# Altium schematic sheet PAGE2-CCTALK CONVERTER.SchDoc
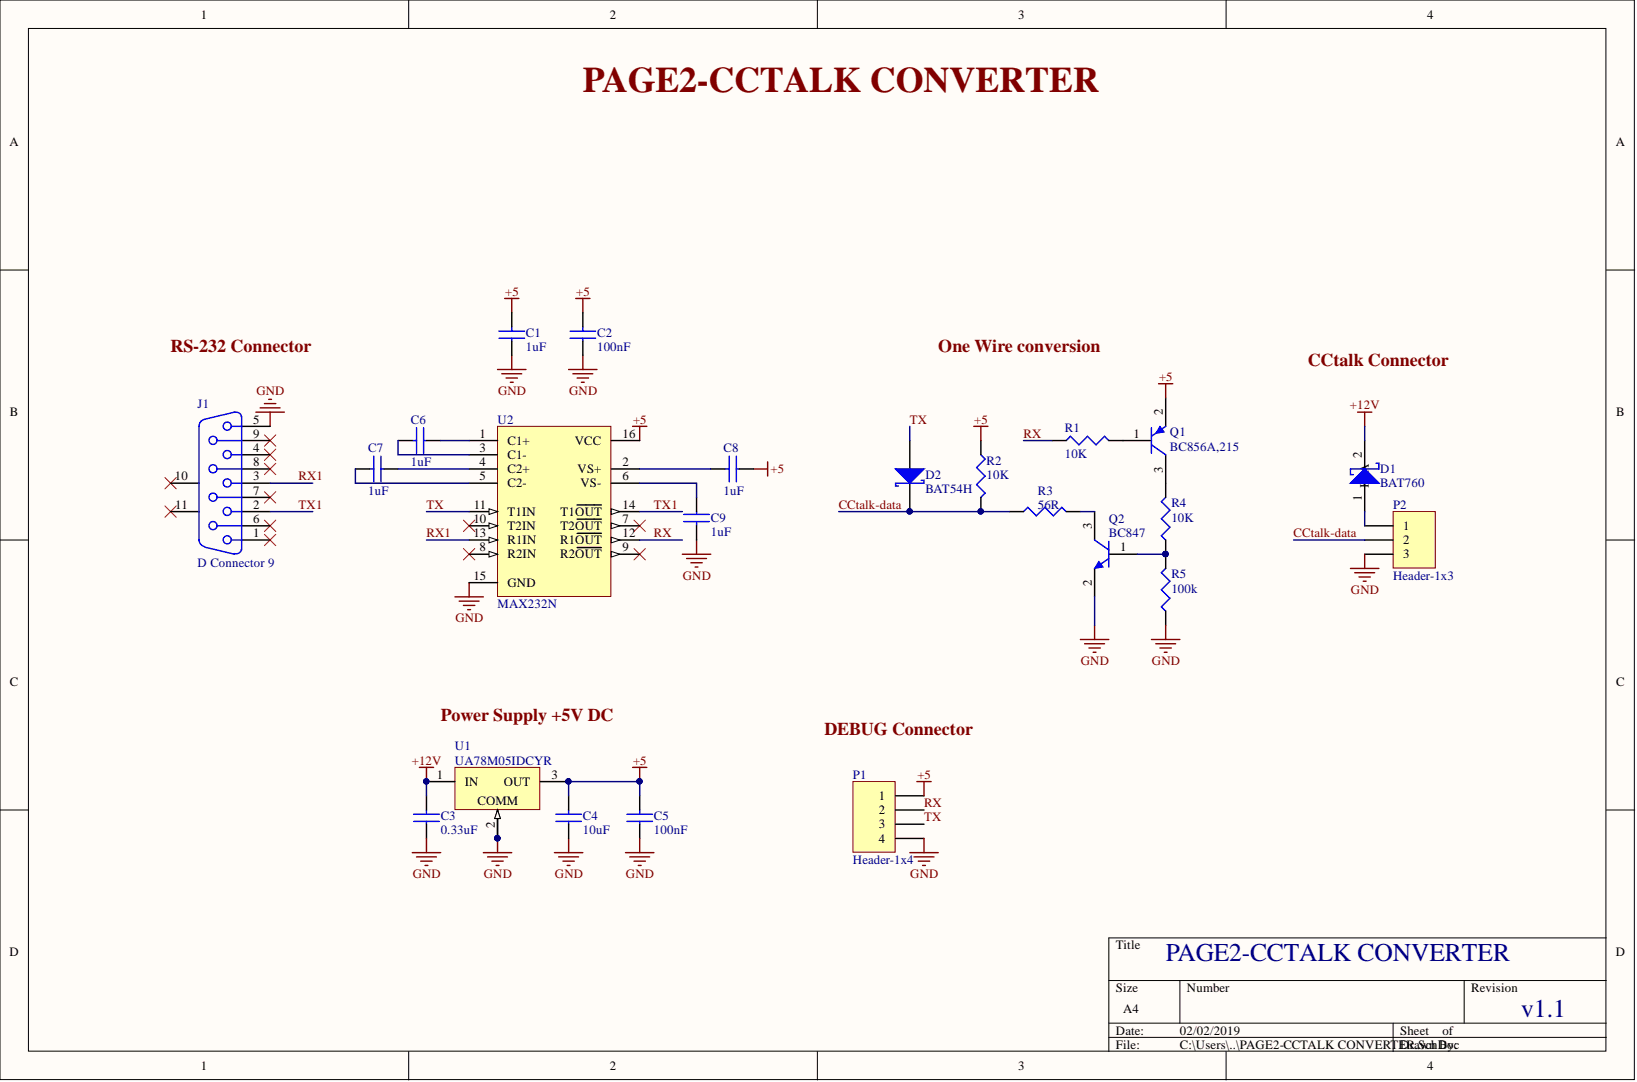
[diagram p0: PAGE2-CCTALK CONVERTER.SchDoc]

## Parts
C1  1uF  [CAP0603]
C2  100nF  [CAP0603]
C3  0.33uF  [CAP0603]
C4  10uF  [CAP0603]
C5  100nF  [CAP0603]
C6  1uF  [CAP0603]
C7  1uF  [CAP0603]
C8  1uF  [CAP0603]
C9  1uF  [CAP0603]
D1  BAT760  [sod-323]
D2  BAT54H  [SOD123]
J1  D Connector 9  [DSUB_9POS_PCB]
P1  Header-1x4  [HDR1X4]
P2  Header-1x3  [HDR_1X3]
Q1  BC856A,215  [SOT23-3]
Q2  BC847  [SOT23-3]
R1  10K  [RES0603]
R2  10K  [RES0603]
R3  56R  [RES0603]
R4  10K  [RES0603]
R5  100k  [RES0603]
U1  UA78M05IDCYR  [sot-223]
U2  MAX232N  [16-SOIC]

## Nets
+5: C1.2 C2.2 C4.2 C5.2 C8.1 P1.1 Q1.2 R2.1 U1.3(OUT) U2.16(VCC)
+12V: C3.2 D1.2 U1.1(IN)
GND: C1.1 C2.1 C3.1 C4.1 C5.1 C9.2 J1.5 P1.4 P2.3 Q2.2 R5.1 U1.2 U1.4 U2.15(GND)
CCTALK-DATA: D2.2 P2.2 R2.2 R3.1
RX: P1.2 R1.1 U2.12(R1OUT)
RX1: J1.3 U2.13(R1IN)
TX: D2.1 P1.3 U2.11(T1IN)
TX1: J1.2 U2.14(T1OUT)
NetC6_1: C6.1 U2.1(C1+)
NetC6_2: C6.2 U2.3(C1-)
NetC7_1: C7.1 U2.4(C2+)
NetC7_2: C7.2 U2.5(C2-)
NetC8_2: C8.2 U2.2(VS+)
NetC9_1: C9.1 U2.6(VS-)
NetD1_1: D1.1 P2.1
NetQ1_1: Q1.1 R1.2
NetQ1_3: Q1.3 R4.2
NetQ2_1: Q2.1 R4.1 R5.2
NetQ2_3: Q2.3 R3.2

Not connected: J1 1 4 6 7 8 9 10 11; U2 7(T2OUT) 8(R2IN) 9(R2OUT) 10(T2IN)

## Library data of the parts
C1 C6-C9 = 1uF: CAP CER 1UF 10V X5R 0603; Manufacturer: KEMET; Manufacturer Part Number: C0603C105K8PACTU; Notification: Current market demand for these product types have resulted in fluctuating and extending lead times. Lead times may differ.; Tolerance: ±10%; Applications: General Purpose; Category: Capacitors; Component Kind: Standard; Failure Rate: -; Features: -; Height - Seated (Max): -; Lead Spacing: -; Lead Style: -; Mounting Type: Surface Mount, MLCC; Operating Temperature: -55°C ~ 85°C; Package / Case: 0603 (1608 Metric); Packaging: Cut Tape (CT); Part Status: Active; Ratings: -; RoHS: RoHS Compliant; Size / Dimension: 0.063" L x 0.032" W (1.60mm x 0.80mm); Supplier: Digi-Key; Supplier 1: Digi-Key; Supplier Part Number: 399-3118-1-ND; Supplier Part Number 1: 399-3118-1-ND; Temperature Coefficient: X5R; Thickness (Max): 0.035" (0.90mm); Voltage - Rated: 10V; Library Name: hepek_lib.SchLib
C2 C5 = 100nF: CAP CER 0.1UF 25V Y5V 0603; Manufacturer: KEMET; Manufacturer Part Number: C0603C104M3VACTU; Notification: Current market demand for these product types have resulted in fluctuating and extending lead times. Lead times may differ.; Temperature Coefficient: Y5V (F); Tolerance: ±20%; Applications: General Purpose; Category: Capacitors; Component Kind: Standard; Failure Rate: -; Features: -; Height - Seated (Max): -; Lead Spacing: -; Lead Style: -; Mounting Type: Surface Mount, MLCC; Operating Temperature: -30°C ~ 85°C; Package / Case: 0603 (1608 Metric); Packaging: Cut Tape (CT); Part Status: Active; Ratings: -; RoHS: RoHS Compliant; Size / Dimension: 0.063" L x 0.032" W (1.60mm x 0.80mm); Supplier: Digi-Key; Supplier 1: Digi-Key; Supplier Part Number: 399-1101-1-ND; Supplier Part Number 1: 399-1101-1-ND; Thickness (Max): 0.034" (0.87mm); Voltage - Rated: 25V; Library Name: hepek_lib.SchLib
C3 = 0.33uF: CAP CER 0.33UF 25V Y5V 0603; Manufacturer: Yageo; Manufacturer Part Number: CC0603ZRY5V8BB334; Notification: Current market demand for these product types have resulted in fluctuating and extending lead times. Lead times may differ.; Temperature Coefficient: Y5V (F); Tolerance: -20%, +80%; Applications: General Purpose; Category: Capacitors; Component Kind: Standard; Failure Rate: -; Features: -; Height - Seated (Max): -; Lead Spacing: -; Lead Style: -; Mounting Type: Surface Mount, MLCC; Operating Temperature: -30°C ~ 85°C; Package / Case: 0603 (1608 Metric); Packaging: Cut Tape (CT); Part Status: Active; Ratings: -; RoHS: RoHS Compliant; Size / Dimension: 0.063" L x 0.031" W (1.60mm x 0.80mm); Supplier: Digi-Key; Supplier 1: Digi-Key; Supplier Part Number: 311-4118-1-ND; Supplier Part Number 1: 311-4118-1-ND; Thickness (Max): 0.035" (0.90mm); Voltage - Rated: 25V; Library Name: hepek_lib.SchLib
C4 = 10uF: CAP CER 10UF 6.3V X5R 0603; Manufacturer: Murata Electronics North America; Manufacturer Part Number: GRM188R60J106ME47D; Notification: Current market demand for these product types have resulted in fluctuating and extending lead times. Lead times may differ.; Tolerance: ±20%; Applications: General Purpose; Category: Capacitors; Component Kind: Standard; Features: -; Height - Seated (Max): -; Lead Spacing: -; Lead Style: -; Mounting Type: Surface Mount, MLCC; Operating Temperature: -55°C ~ 85°C; Package / Case: 0603 (1608 Metric); Packaging: Cut Tape (CT); Part Status: Active; Ratings: -; RoHS: RoHS Compliant; Size / Dimension: 0.063" L x 0.032" W (1.60mm x 0.80mm); Supplier: Digi-Key; Supplier 1: Digi-Key; Supplier Part Number: 490-3896-1-ND; Supplier Part Number 1: 490-3896-1-ND; Temperature Coefficient: X5R; Thickness (Max): 0.035" (0.90mm); Voltage - Rated: 6.3V; Library Name: hepek_lib.SchLib
D1 = BAT760: DIODE SCHOTTKY 30V 1A SOD323; Manufacturer: Diodes Incorporated; Manufacturer Part Number: BAT760-7; Capacitance @ Vr, F: 25pF @ 5V, 1MHz; Speed: Fast Recovery =< 500ns, > 200mA (Io); Tolerance: ±5%; Base Part Number: BAT760; Category: Discrete Semiconductor Products; Component Kind: Standard; Current - Average Rectified (Io): 1A; Diode Type: Schottky; Mounting Type: Surface Mount; Operating Temperature: -65°C ~ 150°C; Operating Temperature - Junction: -65°C ~ 150°C; Package / Case: SC-76, SOD-323; Packaging: Cut Tape (CT); Part Status: Active; RoHS: RoHS Compliant; Supplier: Digi-Key; Supplier 1: Digi-Key; Supplier Device Package: SOD-323; Supplier Part Number: BAT760DICT-ND; Supplier Part Number 1: BAT760DICT-ND; Library Name: hepek_lib.SchLib
D2 = BAT54H: DIODE SCHOTTKY 30V 200MA SOD123F; Manufacturer: Nexperia USA Inc.; Manufacturer Part Number: BAT54H,115; Capacitance @ Vr, F: 10pF @ 1V, 1MHz; Current - Average Rectified (Io): 200mA (DC); Speed: Small Signal =< 200mA (Io), Any Speed; Base Part Number: BAT54H; Category: Discrete Semiconductor Products; Component Kind: Standard; Diode Type: Schottky; Mounting Type: Surface Mount; Operating Temperature - Junction: 125°C (Max); Package / Case: SOD-123F; Packaging: Cut Tape (CT); Part Status: Active; RoHS: RoHS Compliant; Supplier: Digi-Key; Supplier 1: Digi-Key; Supplier Device Package: SOD-123F; Supplier Part Number: 1727-3569-1-ND; Supplier Part Number 1: 1727-3569-1-ND; Library Name: hepek_lib.SchLib
J1 = D Connector 9: CONN DSUB PLUG 9POS STR SLDR CUP; Manufacturer: Assmann WSW Components; Manufacturer Part Number: A-DS 09 LL/Z; Connector Style: D-Sub; Connector Type: Plug, Male Pins; Current Rating: 3A; Flange Feature: Housing/Shell (Unthreaded); Gender: Receptacle; Material Flammability Rating: UL94 V-0; Number of Positions: 9; PackageDocument: O; Voltage Rating: 250V; Backset Spacing: -; Category: Connectors, Interconnects; Color: White; Component Kind: Standard; Contact Finish: Gold; Contact Finish Thickness: Flash; Contact Form: -; Contact Material: Copper Alloy; Contact Type: Signal; DatasheetDocument: 1-Sep-1999; Features: Grounding Indents; Housing Material: Polybutylene Terephthalate (PBT), Glass Filled; Ingress Protection: -; LatestRevisionDate: 17-Jul-2002; LatestRevisionNote: Re-released for DXP Platform.; Mounting Type: Free Hanging (In-Line); Number of Rows: 2; Operating Temperature: -55°C ~ 105°C; Orientation: Right Angle; PackageReference: 788750; Packaging: Tray; Part Status: Active; Positions: 9; Published: 15-Jun-2001; RoHS: RoHS Compliant; Shell Material, Finish: Steel; Shell Size, Connector Layout: 1 (DE, E); Supplier: Digi-Key; Supplier 1: Digi-Key; Supplier Part Number: AE10972-ND; Supplier Part Number 1: AE10972-ND; Termination: Solder Cup; Wire Gauge: -; Library Name: hepek_lib.SchLib; Publisher: Altium Limited
P1 = Header 4: Header, 4-Pin; Component Kind: Standard; LatestRevisionDate: 17-Jul-2002; LatestRevisionNote: Re-released for DXP Platform.; Library Name: Miscellaneous Connectors.IntLib; Signal Integrity: Connector; Publisher: Altium Limited
P2 = header_3: Header, 3-Pin; Component Kind: Standard; LatestRevisionDate: 17-Jul-2002; LatestRevisionNote: Re-released for DXP Platform.; Signal Integrity: Connector; Library Name: hepek_lib.SchLib; Publisher: Altium Limited
Q1 = BC856A,215: TRANS PNP 65V 0.1A SOT23; Manufacturer: Nexperia USA Inc.; Current - Collector Cutoff (Max): 15nA (ICBO); DC Current Gain (hFE) (Min) @ Ic, Vce: 125 @ 2mA, 5V; Frequency - Transition: 100MHz; Vce Saturation (Max) @ Ib, Ic: 650mV @ 5mA, 100mA; Base Part Number: BC856; Category: Discrete Semiconductor Products; Component Kind: Standard; Manufacturer Part Number: BC856A,215; Mounting Type: Surface Mount; Operating Temperature: 150°C (TJ); Package / Case: TO-236-3, SC-59, SOT-23-3; Packaging: Cut Tape (CT); Part Status: Active; RoHS: RoHS Compliant; Supplier: Digi-Key; Supplier 1: Digi-Key; Supplier Device Package: TO-236AB; Supplier Part Number: 1727-4242-1-ND; Supplier Part Number 1: 1727-4242-1-ND; Transistor Type: PNP; Library Name: hepek_lib.SchLib
Q2 = BC847: TRANS NPN 45V 0.1A SOT23; Manufacturer: ON Semiconductor; Manufacturer Part Number: BC847ALT1G; Current - Collector Cutoff (Max): 15nA (ICBO); DC Current Gain (hFE) (Min) @ Ic, Vce: 110 @ 2mA, 5V; Frequency - Transition: 100MHz; Vce Saturation (Max) @ Ib, Ic: 600mV @ 5mA, 100mA; Category: Discrete Semiconductor Products; Component Kind: Standard; Mounting Type: Surface Mount; Operating Temperature: -55°C ~ 150°C (TJ); Package / Case: TO-236-3, SC-59, SOT-23-3; Packaging: Cut Tape (CT); Part Status: Active; RoHS: RoHS Compliant; Supplier: Digi-Key; Supplier 1: Digi-Key; Supplier Device Package: SOT-23-3 (TO-236); Supplier Part Number: BC847ALT1GOSCT-ND; Supplier Part Number 1: BC847ALT1GOSCT-ND; Transistor Type: NPN; Library Name: hepek_lib.SchLib
R1 R2 R4 = 10K: MULTICOMP - MCSR06X1002FTL - RES, CERAMIC, 10K, 1%, 0.1W, 0603; Manufacturer: Vishay Beyschlag; Manufacturer Part Number: MCT06030C1002FP500; Power (Watts): 0.125W, 1/8W; Power Rating: 100; Product Range: MCSR 06 Series; Resistance: 10; Resistor Case Style: 0603 [1608 Metric]; Resistor Mounting: Surface Mount Device<!--FANDB--><div class="features-and-benefits-container"><div class="features-and-benefits-description"><span class="features-benefits-value">The MCSR 06 series anti-sulfuration Chip Resistor constructed in a high grade ceramic body (aluminium oxide). Internal metal electrodes are added at each end and connected by a resistive paste that is applied to the top surface of the substrate. The composition of the paste is adjusted to give the approximate resistance required and the value is trimmed to within tolerance by laser cutting of this resistive layer. The resistive layer is covered with a protective coat. Finally, the two external end terminations are added. For ease of soldering the outer layer of these end terminations is a tin (lead-free) alloy.</span></div><div class="features-and-benefits-list"><ul class="features-and-benefits-list-list"><li class="features-and-benefits-list-item">Laser trimmed</li><li class="features-and-benefits-list-item">Resistive element</li><li class="features-and-benefits-list-item">100; SVHC: No SVHC (12-Jan-2017); Temperature Coefficient: ±50ppm/°C; Voltage Rating: 75; Automotive Qualification Standard: -; Category: Resistors; Component Kind: Standard; ComponentLink1Description: http://www.farnell.com/datasheets/1716711.pdf; ComponentLink1URL: http://www.farnell.com/datasheets/1716711.pdf; Composition: Thin Film; Failure Rate: -; Features: Anti-Sulfur; Height - Seated (Max): 0.022" (0.55mm); Operating Temperature: -55°C ~ 155°C; Package / Case: 0603 (1608 Metric); Packaging: Cut Tape (CT); Part Status: Active; Resistance Tolerance: ± 1%; Resistor Element Material: Ceramic; RoHS: RoHS Compliant; Size / Dimension: 0.061" L x 0.033" W (1.55mm x 0.85mm); Supplier: Digi-Key; Supplier 1: Digi-Key; Supplier Device Package: 0603; Supplier Part Number: MCT0603-10.0K-CFCT-ND; Supplier Part Number 1: MCT0603-10.0K-CFCT-ND; Tolerance: ±1%; Library Name: hepek_lib.SchLib
R3 = 56R: Manufacturer: Yageo; Manufacturer Part Number: RC0603JR-0756RL; Power (Watts): 0.1W, 1/10W; Temperature Coefficient: ±100ppm/°C; Tolerance: ±5%; Category: Resistors; Component Kind: Standard; Composition: Thick Film; Failure Rate: -; Features: Moisture Resistant; Height - Seated (Max): 0.022" (0.55mm); Operating Temperature: -55°C ~ 155°C; Package / Case: 0603 (1608 Metric); Packaging: Cut Tape (CT); Part Status: Active; RoHS: RoHS Compliant; Size / Dimension: 0.063" L x 0.032" W (1.60mm x 0.80mm); Supplier: Digi-Key; Supplier 1: Digi-Key; Supplier Device Package: 0603; Supplier Part Number: 311-56GRCT-ND; Supplier Part Number 1: 311-56GRCT-ND; Library Name: hepek_lib.SchLib
R5 = 100k: RES SMD 100K OHM 0.5% 1/16W 0603; Manufacturer: Susumu; Manufacturer Part Number: RR0816P-104-D; Power (Watts): 0.063W, 1/16W; Temperature Coefficient: ±25ppm/°C; Category: Resistors; Component Kind: Standard; Composition: Thin Film; Failure Rate: -; Features: -; Height - Seated (Max): 0.020" (0.50mm); Operating Temperature: -55°C ~ 125°C; Package / Case: 0603 (1608 Metric); Packaging: Cut Tape (CT); Part Status: Active; RoHS: RoHS Compliant; Size / Dimension: 0.063" L x 0.032" W (1.60mm x 0.80mm); Supplier: Digi-Key; Supplier 1: Digi-Key; Supplier Device Package: -; Supplier Part Number: RR08P100KDCT-ND; Supplier Part Number 1: RR08P100KDCT-ND; Tolerance: ±0.5%; Library Name: hepek_lib.SchLib
U1 = UA78M05IDCYR: IC REG LINEAR 5V 500MA SOT223-4; Manufacturer: Texas Instruments; Output Configuration: Positive; Output Type: Fixed; Protection Features: Over Current, Over Temperature, Short Circuit; PSRR: 80dB (120Hz); Voltage - Input (Max): 25V; Voltage Dropout (Max): 2V @ 350mA; Base Part Number: UA78M05; Category: Integrated Circuits (ICs); Component Kind: Standard; Control Features: -; Current - Output: 500mA; Manufacturer Part Number: UA78M05IDCY; Mounting Type: Surface Mount; Operating Temperature: -40°C ~ 125°C; Package / Case: TO-261-4, TO-261AA; Packaging: Tube; Part Status: Active; RoHS: RoHS Compliant; Supplier: Digi-Key; Supplier 1: Digi-Key; Supplier Device Package: SOT-223-4; Supplier Part Number: 296-27139-5-ND; Supplier Part Number 1: 296-27139-5-ND; Voltage - Output (Max): -; Voltage - Output (Min/Fixed): 5V; Library Name: hepek_lib.SchLib
U2 = MAX232N: Dual EIA-232 Driver/Receiver; Manufacturer: Texas Instruments; Manufacturer Part Number: MAX232DR; Data Rate: 120Kbps; Duplex: Full; Note: Receivers have typical hysteresis of 0.5V; Number of Drivers/Receivers: 2/2; Protocol: RS232; Voltage - Supply: 4.5 V ~ 5.5 V; Base Part Number: MAX232; Category: Integrated Circuits (ICs); Code_JEDEC: MS-001-BB; Component Kind: Standard; ComponentLink1Description: Manufacturer Link; ComponentLink1URL: http://www.ti.com/; ComponentLink2Description: Datasheet; ComponentLink2URL: http://www-s.ti.com/sc/ds/max232.pdf; DatasheetVersion: Jun-1989; LatestRevisionDate: 04-Mar-2005; LatestRevisionNote: Stylized 3D Model Added.; Mounting Type: Surface Mount; Operating Temperature: 0°C ~ 70°C; Package / Case: 16-SOIC (0.154", 3.90mm Width); PackageDescription: DIP; 16 Leads; Row Spacing 7.62 mm; Pitch 2.54 mm; PackageReference: N016; PackageVersion: Oct-1995; Packaging: Cut Tape (CT); Part Status: Active; Published: 15-May-2002; RoHS: RoHS Compliant; Supplier: Digi-Key; Supplier 1: Digi-Key; Supplier Device Package: 16-SOIC; Supplier Part Number: 296-14619-1-ND; Supplier Part Number 1: 296-14619-1-ND; Type: Transceiver; Library Name: hepek_lib.SchLib; Publisher: Altium Limited
